# Altium schematic sheet BMS Schematic.SchDoc
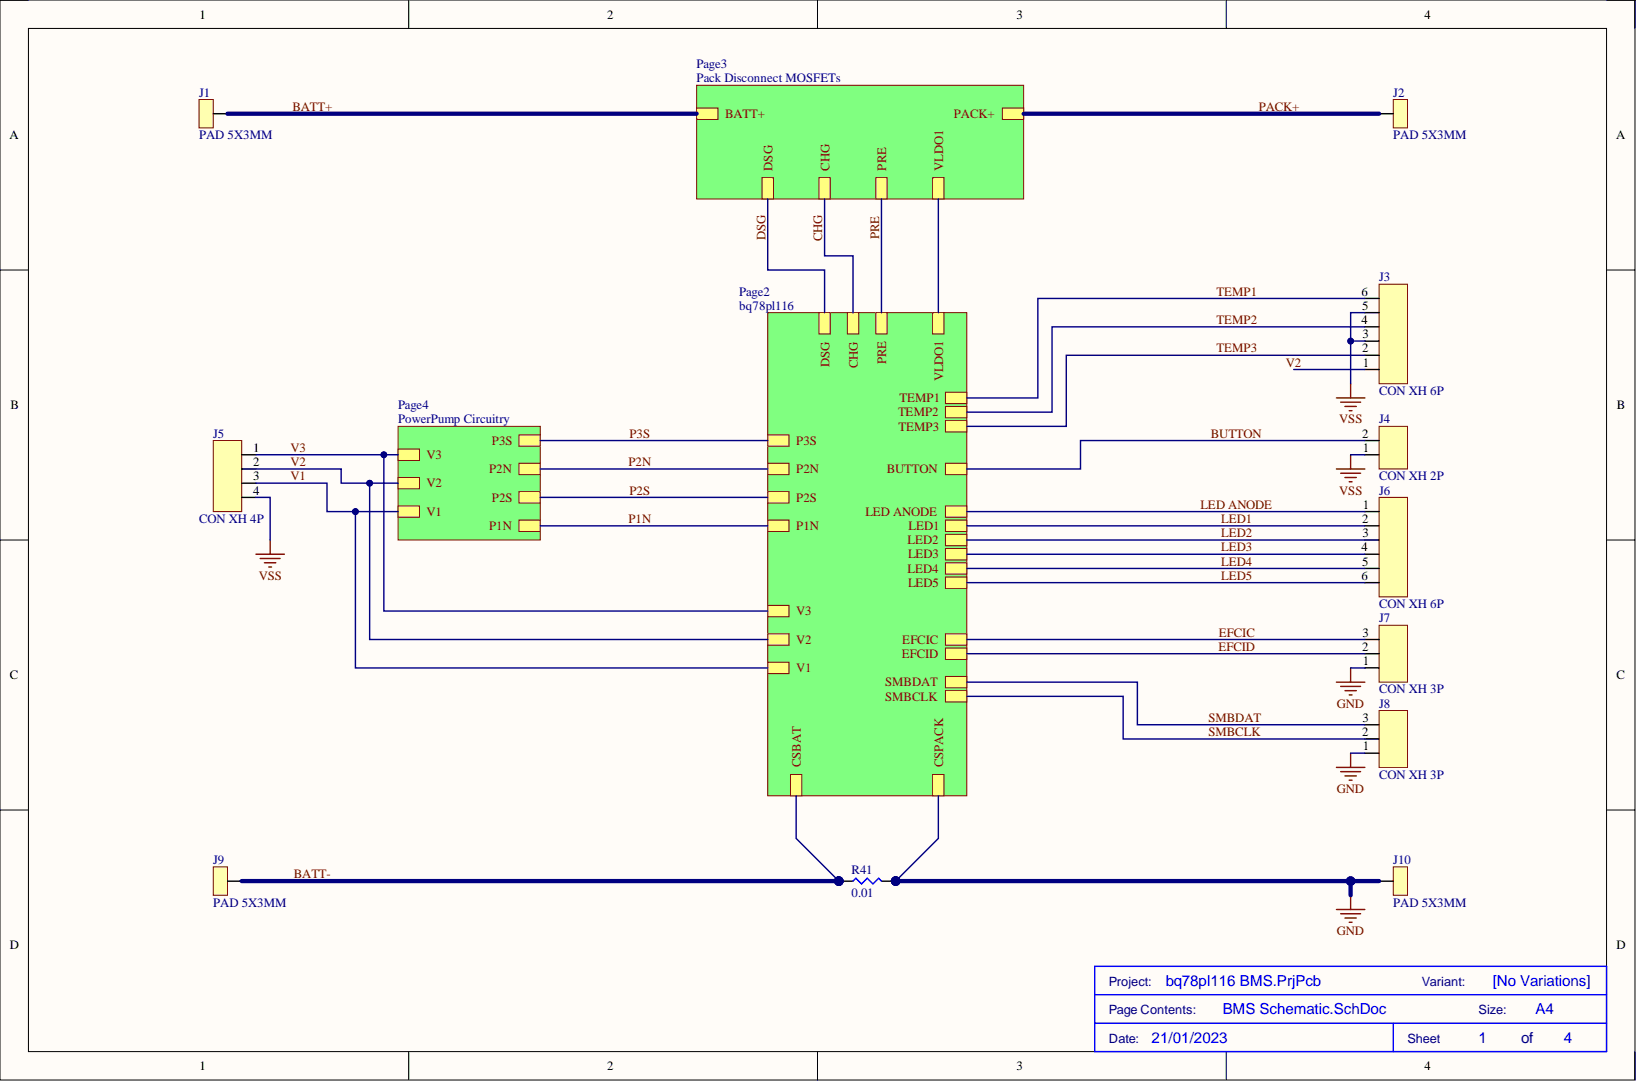
[diagram p0: BMS Schematic.SchDoc]

## Parts
J1  PAD 5X3MM  [PAD 5X3MM]
J2  PAD 5X3MM  [PAD 5X3MM]
J3  CON XH 6P  [XH 2.5 6P]
J4  CON XH 2P  [XH 2.5 2P]
J5  CON XH 4P  [XH 2.5 4P]
J6  CON XH 6P  [XH 2.5 6P]
J7  CON XH 3P  [XH 2.5 3P]
J8  CON XH 3P  [XH 2.5 3P]
J9  PAD 5X3MM  [PAD 5X3MM]
J10  PAD 5X3MM  [PAD 5X3MM]
R41  0.01  [RSHUNT]

## Nets
GND: J7.1 J8.1 J10.1 R41.2
VSS: J3.3 J3.5 J4.1 J5.4
BATT+: J1.1
BATT-: J9.1 R41.1
BUTTON: J4.2
EFCIC: J7.3
EFCID: J7.2
LED1: J6.2
LED2: J6.3
LED3: J6.4
LED4: J6.5
LED5: J6.6
LED ANODE: J6.1
PACK+: J2.1
SMBCLK: J8.2
SMBDAT: J8.3
TEMP1: J3.6
TEMP2: J3.4
TEMP3: J3.2
V1: J5.3
V2: J3.1 J5.2
V3: J5.1
CHG:
DSG:
P1N:
P2N:
P2S:
P3S:
PRE:
VLDO1:

## Library data of the parts
J3 J6 = CONN XH 2.5 6P: CONN HEADER VERT 6POS 2.5MM; Manufacturer: JST; Manufacturer Part Number: B6BXHA(LF)(SN); Category: FFC / FPC; Component Kind: Standard; ComponentLink1Description: https://datasheet.ciiva.com/26894/getdatasheetpartid-424647-26894806.pdf?src-supplier=Verical; ComponentLink1URL: https://datasheet.ciiva.com/26894/getdatasheetpartid-424647-26894806.pdf?src-supplier=Verical; ComponentLink2Description: https://datasheet.ciiva.com/26894/getdatasheetpartid-641012-26894489.pdf?src-supplier=Verical; ComponentLink2URL: https://datasheet.ciiva.com/26894/getdatasheetpartid-641012-26894489.pdf?src-supplier=Verical; ComponentLink3Description: https://datasheet.ciiva.com/712/exh-712511.pdf?src-supplier=Digi-Key; ComponentLink3URL: https://datasheet.ciiva.com/712/exh-712511.pdf?src-supplier=Digi-Key; ComponentLink4Description: https://datasheet.ciiva.com/16397/b6b-xh-alfsn-16397116.pdf?src-supplier=Future+Electronics; ComponentLink4URL: https://datasheet.ciiva.com/16397/b6b-xh-alfsn-16397116.pdf?src-supplier=Future+Electronics; Library Name: MyLibrary.IntLib; RoHS: Yes; Supplier 1: Distrelec; Supplier Part Number 1: 14301998
J4 = CONN XH 2.5 2P: 2 Position Dual Row 2.5 mm Pitch Through Hole Shrouded Header; Manufacturer: JST; Manufacturer Part Number: B2B-XH-A-GU; Category: Headers and Wire Housings; Component Kind: Standard; ComponentLink1Description: https://datasheet.ciiva.com/16417/exh-16417678.pdf?src-supplier=Future+Electronics; ComponentLink1URL: https://datasheet.ciiva.com/16417/exh-16417678.pdf?src-supplier=Future+Electronics; Library Name: MyLibrary.IntLib; Pricing 1: 1=0,24000, 10=0,20400, 100=0,15680, 500=0,13270, 1000=0,10858, 5000=0,09652, 10000=0,08747 (USD); RoHS: Yes; Stock 1: 22513; Supplier 1: Digi-Key; Supplier Part Number 1: 455-2946-ND
J5 = CONN XH 2.5 4P: XH Series 4 Position 2.5 mm Pitch Through Hole Crimp Top Entry Shrouded Header; Manufacturer: JST; Manufacturer Part Number: B4B-XH-A(LF)(SN); Category: FFC / FPC; Component Kind: Standard; ComponentLink1Description: https://datasheet.ciiva.com/16203/b4b-xh-alfsn-16203642.pdf?src-supplier=Future+Electronics; ComponentLink1URL: https://datasheet.ciiva.com/16203/b4b-xh-alfsn-16203642.pdf?src-supplier=Future+Electronics; ComponentLink2Description: https://datasheet.ciiva.com/26924/getdatasheetpartid-640571-26924035.pdf?src-supplier=Verical; ComponentLink2URL: https://datasheet.ciiva.com/26924/getdatasheetpartid-640571-26924035.pdf?src-supplier=Verical; ComponentLink3Description: https://datasheet.ciiva.com/26894/getdatasheetpartid-455616-26894787.pdf?src-supplier=Verical; ComponentLink3URL: https://datasheet.ciiva.com/26894/getdatasheetpartid-455616-26894787.pdf?src-supplier=Verical; ComponentLink4Description: https://datasheet.ciiva.com/726/exh-726404.pdf?src-supplier=Digi-Key; ComponentLink4URL: https://datasheet.ciiva.com/726/exh-726404.pdf?src-supplier=Digi-Key; Library Name: MyLibrary.IntLib; Pricing 1: 5=0,42800, 125=0,15600, 500=0,14600, 1250=0,13600, 2500=0,12800 (GBP); RoHS: Yes; Stock 1: 10520; Supplier 1: RSComponents; Supplier Part Number 1: 8201551
J7 J8 = CONN XH 2.5 3P: Connector Header, XH Series, 3 Position, 2.50mm, Through Hole, Press-Fit, Solder, Natural; Manufacturer: JST; Manufacturer Part Number: B3B-XH-A(LF)(SN); Category: Headers and Wire Housings; Component Kind: Standard; ComponentLink1Description: https://datasheet.ciiva.com/26894/getdatasheetpartid-640434-26894454.pdf?src-supplier=Verical; ComponentLink1URL: https://datasheet.ciiva.com/26894/getdatasheetpartid-640434-26894454.pdf?src-supplier=Verical; ComponentLink2Description: https://datasheet.ciiva.com/26894/getdatasheetpartid-440399-26894778.pdf?src-supplier=Verical; ComponentLink2URL: https://datasheet.ciiva.com/26894/getdatasheetpartid-440399-26894778.pdf?src-supplier=Verical; ComponentLink3Description: https://datasheet.ciiva.com/11746/0900766b8082653f-11746520.pdf?src-supplier=RSComponents; ComponentLink3URL: https://datasheet.ciiva.com/11746/0900766b8082653f-11746520.pdf?src-supplier=RSComponents; Library Name: MyLibrary.IntLib; Pricing 1: 1000=0,07100 (GBP); RoHS: Yes; Stock 1: 43000; Supplier 1: RSComponents; Supplier Part Number 1: 1724888
R41 = SHUNT 10m: Component Kind: Standard; Library Name: MyLibrary.IntLib
J1 J2 J9 J10 = PAD 5X3MM: Component Kind: Standard; Library Name: MyLibrary.IntLib
